# Altium schematic sheet BSPD_SHEET_1.SchDoc(BSPD_SHEET_1)
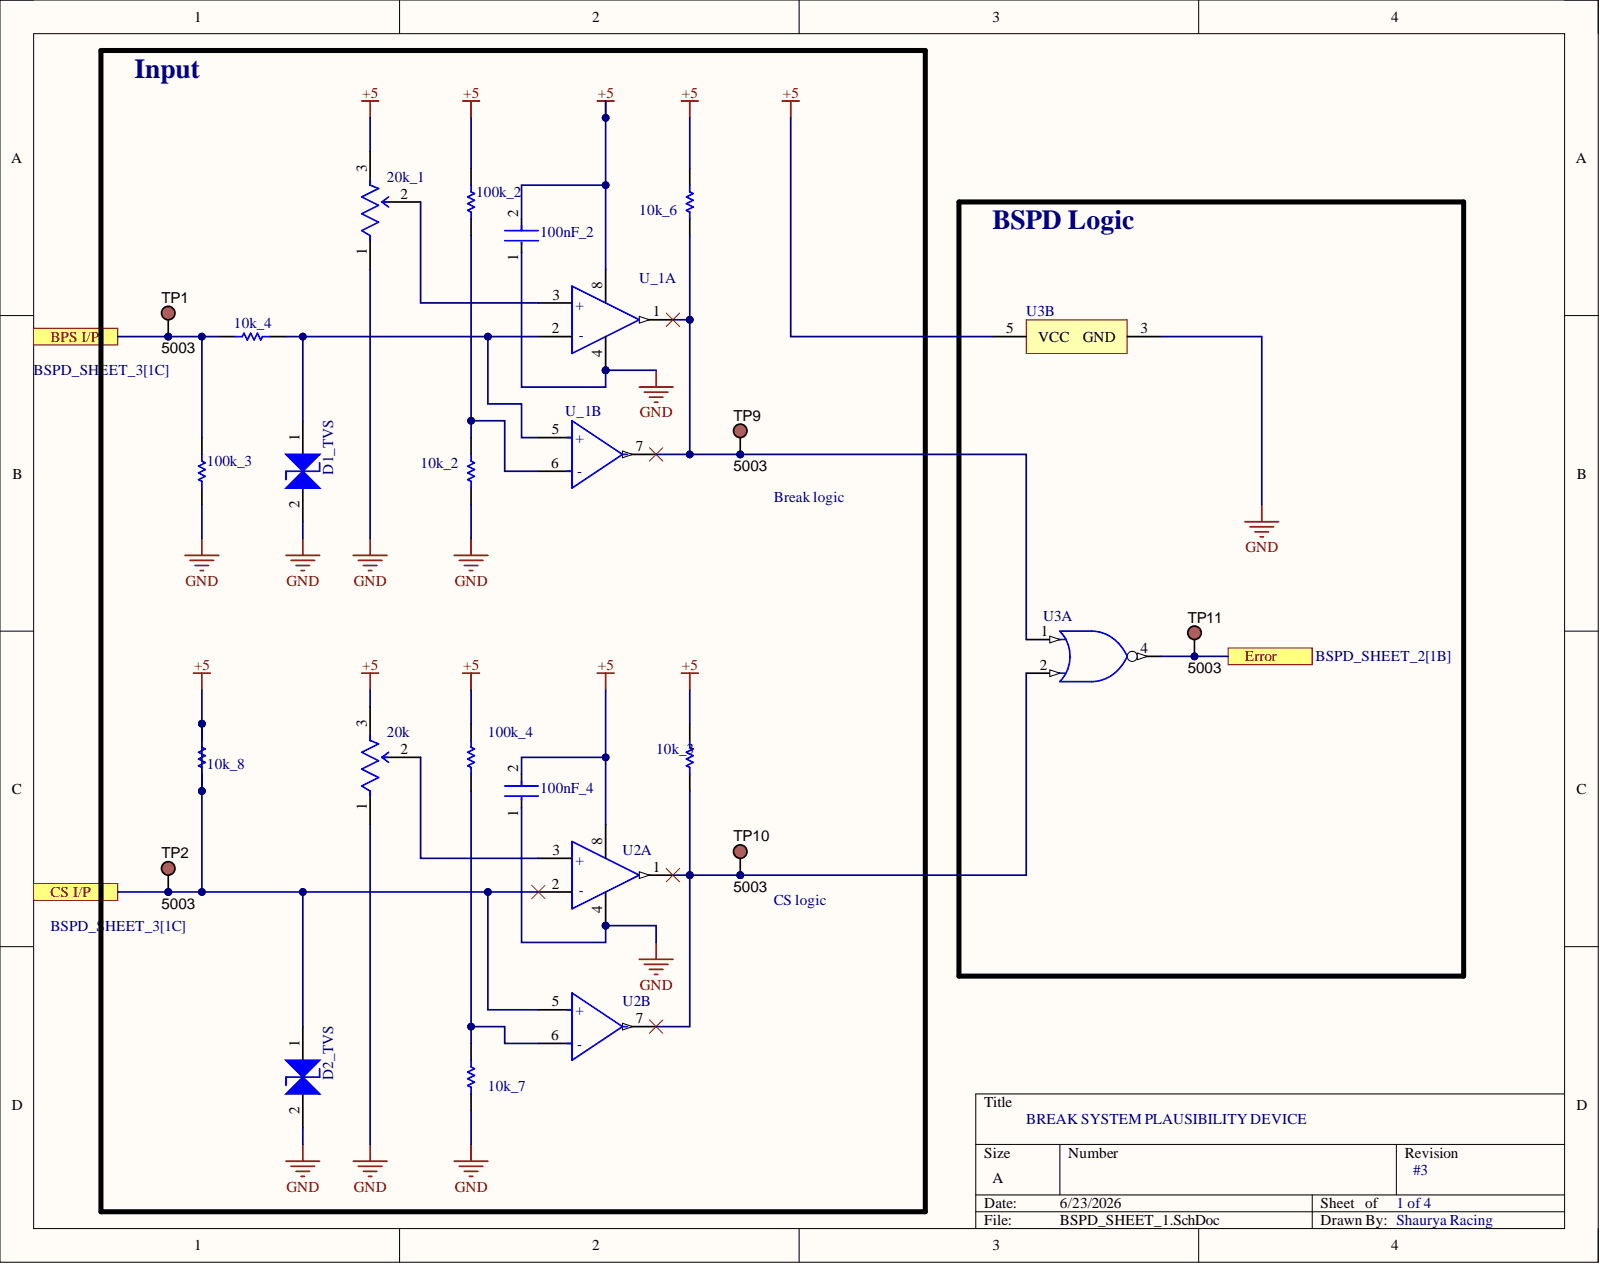
[diagram p0: BSPD_SHEET_1.SchDoc]

## Parts
10k_2  TNPW040210K0DEED  (value not drawn)
10k_3  10
10k_4  TNPW040210K0DEED  (value not drawn)
10k_6  TNPW040210K0DEED  (value not drawn)
10k_7  TNPW040210K0DEED  (value not drawn)
10k_8  TNPW040210K0DEED  (value not drawn)
20k  3290W-1-203  (value not drawn)
20k_1  3290W-1-203  (value not drawn)
100k_2  100K 1% 0603(1608)  (value not drawn)
100k_3  100K 1% 0603(1608)  (value not drawn)
100k_4  100K 1% 0603(1608)  (value not drawn)
100nF_2  AC1210KKX7R0BB104  (value not drawn)
100nF_4  AC1210KKX7R0BB104  (value not drawn)
D1_TVS  824032815  (value not drawn)
D2_TVS  824032815  (value not drawn)
TP1  5003
TP2  5003
TP9  5003
TP10  5003
TP11  5003
U2  LM393D  (value not drawn)  (parts A,B)
U3  SN74AHC1G02DCKR  (value not drawn)  (parts A,B)
U_1  LM393D  (value not drawn)  (parts A,B)

## Ports
BPS I/P, CS I/P, Error

## Nets
GND: 10k_2.2 10k_7.1 20k.1 20k_1.1 100k_3.1 100nF_2.1 100nF_4.1 D1_TVS.2 D2_TVS.2 U2.4 U3.3(GND) U_1.4
+5: 10k_3.2 10k_6.1 10k_8.1 10k_8.2 20k.3 20k_1.3 100k_2.2 100k_4.2 100nF_2.2 100nF_4.2 D2_TVS.1 TP2.1 U2.2(-) U2.5(+) U2.8 U3.5(VCC) U_1.8 ; port CS I/P
BPS I/P: 10k_4.1 100k_3.2 TP1.1 ; port BPS I/P
Error: TP11.1 U3.4 ; port Error
Net10k_2_1: 10k_2.1 100k_2.1 U_1.6(-)
Net10k_3_1: 10k_3.1 TP10.1 U2.1 U2.7 U3.2
Net10k_4_2: 10k_4.2 D1_TVS.1 U_1.2(-) U_1.5(+)
Net10k_6_2: 10k_6.2 TP9.1 U3.1 U_1.1 U_1.7
Net10k_7_2: 10k_7.2 100k_4.1 U2.6(-)
Net20k_1_2: 20k_1.2 U_1.3(+)
Net20k_2: 20k.2 U2.3(+)

## Library data of the parts
10k_2 10k_4 10k_6-10k_8 = CMP-2002-01682-1: Manufacturer: Vishay BCcomponents; Component Kind: Standard; Library Name: Altium Content Vault; Part Number: TNPW040210K0DEED
10k_3 = CMP-2002-01682-1: Manufacturer: Vishay BCcomponents; Part Number: TNPW040210K0DEED; Component Kind: Standard; Library Name: Altium Content Vault
20k 20k_1 = CMP-2000-05581-2: NexarPartId: 973867; Max Power Dissipation: 1W; Number of Contacts: 3; Number of Turns: 25; Resistance: 20kΩ; Temperature Coefficient: 50ppm/°C; Tolerance: 5%; Voltage Rating (DC): 500V; Component Kind: Standard; Composition: Wirewound; Depth: 3.81mm; Height: 9.53mm; Length: 9.53mm; Library Name: Altium Content Vault; Max Operating Temperature: 150°C; Military Standard: Not; Min Operating Temperature: -65°C; Mount: Panel, Through Hole; Power Rating: 1W; Radiation Hardening: No; REACH SVHC: No SVHC; RoHS: Compliant; Taper: Linear; Termination: Pin; Weight: 708.738078mg; Width: 9.53mm
100k_2-100k_4 = CMP-1012-00736-1: 100K 0.1W 1% 0603 (1608 Metric)  SMD; Case-EIA: 0603; Case-Metric: 1608; Value: 100000.00 Ohm; Component Kind: Standard; Library Name: Altium Content Vault; Power: 0.1 W; Technology: SMT; Tolerance: 1 %
100nF_2 100nF_4 = CMP-03422-000642-1: CAP CER 0.1UF 100V X7R 1210; Manufacturer: Yageo; Automotive Grade: Grade 1; Capacitance: 100 nF; Temperature Coefficient: 15%; Tolerance: 0.1; Value: 100 nF; Automotive: Yes; Category: Cap; Component Kind: Standard; ComponentLink2Description: Datasheet; ComponentLink2URL: http://www.yageo.com/documents/recent/UPY-AC_NP0X7R_16V-to-630V_12.pdf; Device Class L1: Passive Components; Device Class L2: Capacitors; Device Class L3: Ceramic Capacitors; Digikey Description: CAP CER 0.1UF 100V X7R 1210; Footprint Url: http://www.yageo.com/exep/pages/download/literatures/UPY-C_GEN_24.pdf; Height: 0.95mm; Ipc Land Pattern Name: CAPC320250X85; Lead Free: Yes; Library Name: Altium Content Vault; Manufacturer Part Number: AC1210KKX7R0BB104; Material: Ceramic; Octopart: https://octopart.com/ac1210kkx7r0bb104-yageo-78873719; Package: AC1210-0.85; RoHS: TRUE; Temperature: -55°C to +125°C; Temperature Characteristic: X7R; Temperature Range High: +125°C; Temperature Range Low: -55°C; Voltage Rating: 100 V
D1_TVS D2_TVS = CMP-03913-133963841-2: Tvs Diode, Bidir, 5V, Dfn1610 Rohs Compliant: Yes; Component Kind: Standard; Height: 500µm; Length: 1.6mm; Library Name: Altium Content Vault; Max Operating Temperature: 85°C; Min Operating Temperature: -55°C; RoHS: Compliant; Width: 1mm
TP1 TP2 TP9-TP11 = CMP-002-00037-2: Test Point, Orange, Height 4.6 mm, Tail Length 3 mm, 1-Pin THD, RoHS; Manufacturer: Keystone; Case/Package: 5003; Colour: Orange; Component Kind: Standard; Connector Type: TestPoint; Contact Material: Bronze; Contact Plating: Tin; Datasheet URL: http://keyelco.com/userAssets/file/M65p56.pdf; Datasheet Version: Jun-15; Diameter: 2.54mm; Manufacturer URL: http://www.keyelco.com/; Mounting Technology: TH; Package Description: 1-Pin Through Hole Device, Dia 2.54 mm; Package Quantity: 10; Package Reference: 5003; Packaging: Bulk; Pins: 1; RoHS Compliant: True; Library Name: APAC - VIT, Chennai - Shaurya Racing
U2 U_1 = CMP-12132-000003-1: IC VOLT COMPARATOR DUAL LP 8SOIC; Manufacturer: STMicroelectronics; Input Bias Current: 0.02uA; Input Offset Voltage: 1mV; Max Dual Supply Voltage: 18V; Max Junction Temp: +150°C; Max Supply Voltage: 36V; Min Dual Supply Voltage: 1V; Min Supply Voltage: 2V; Nominal Supply Current: 0.45-0.6mA; Number Of Channels: 2; Output Current Per Channel: 18mA; Response Time: 1.3us; Standoff Height: 0.1mm; Voltage Gain: 200V/mV; Ambient Temperature Range High: +70°C; Ambient Temperature Range Low: 0°C; Automotive: No; Category: IC; Component Kind: Standard; ComponentLink2Description: Datasheet; ComponentLink2URL: https://www.st.com/resource/en/datasheet/lm393.pdf; Device Class L1: Integrated Circuits (ICs); Device Class L2: Linear ICs; Device Class L3: Comparators; Digikey Description: IC VOLT COMPARATOR DUAL LP 8SOIC; Footprint Url: https://www.st.com/resource/en/datasheet/stm8l001j3.pdf; Height: 1.75mm; Lead Free: Yes; Library Name: Altium Content Vault; Manufacturer Part Number: LM393D; Mouser Description: Analog Comparators Lo-Pwr Dual Voltage; Octopart: https://octopart.com/lm393d-stmicroelectronics-25832; Package: SO8; RoHS: TRUE; Temperature: 0°C to +70°C; Temperature Range High: +70°C; Temperature Range Low: 0°C
U3 = CMP-0859-00418-3: Single 2-Input Positive-NOR Gate, DCK0005A, LARGE T&R; Manufacturer: Texas Instruments; 3-State Output: No; Bits(#): 1; F @ Nom Voltage(Max)(Mhz): 75; Gate Type: NOR; ICC @ Nom Voltage(Max)(mA): 0.01; Input Type: CMOS; Logic: True; Output Drive (IOL/IOH)(Max)(mA): 50/-50; Rating: Catalog; Sub-Family: NAND Gate; Technology Family: AHC; tpd @ Nom Voltage(Max)(ns): 11.4,7.5; VCC(Max)(V): 5.5; VCC(Min)(V): 2; Voltage(Nom)(V): 3.3,5; Component Kind: Standard; DatasheetVersion: SCLS342M; Generic Part Number: SN74AHC1G02; Library Name: Altium Content Vault; Operating Temperature Range(C): -40 to 125,-40 to 85; Output Type: CMOS; PackageReference: DCK0005A; Schmitt Trigger: No
